# Altium schematic sheet [13] - FPGA BANKS 34 and 35.SchDoc("[13] - FPGA BANKS 34 and 35")
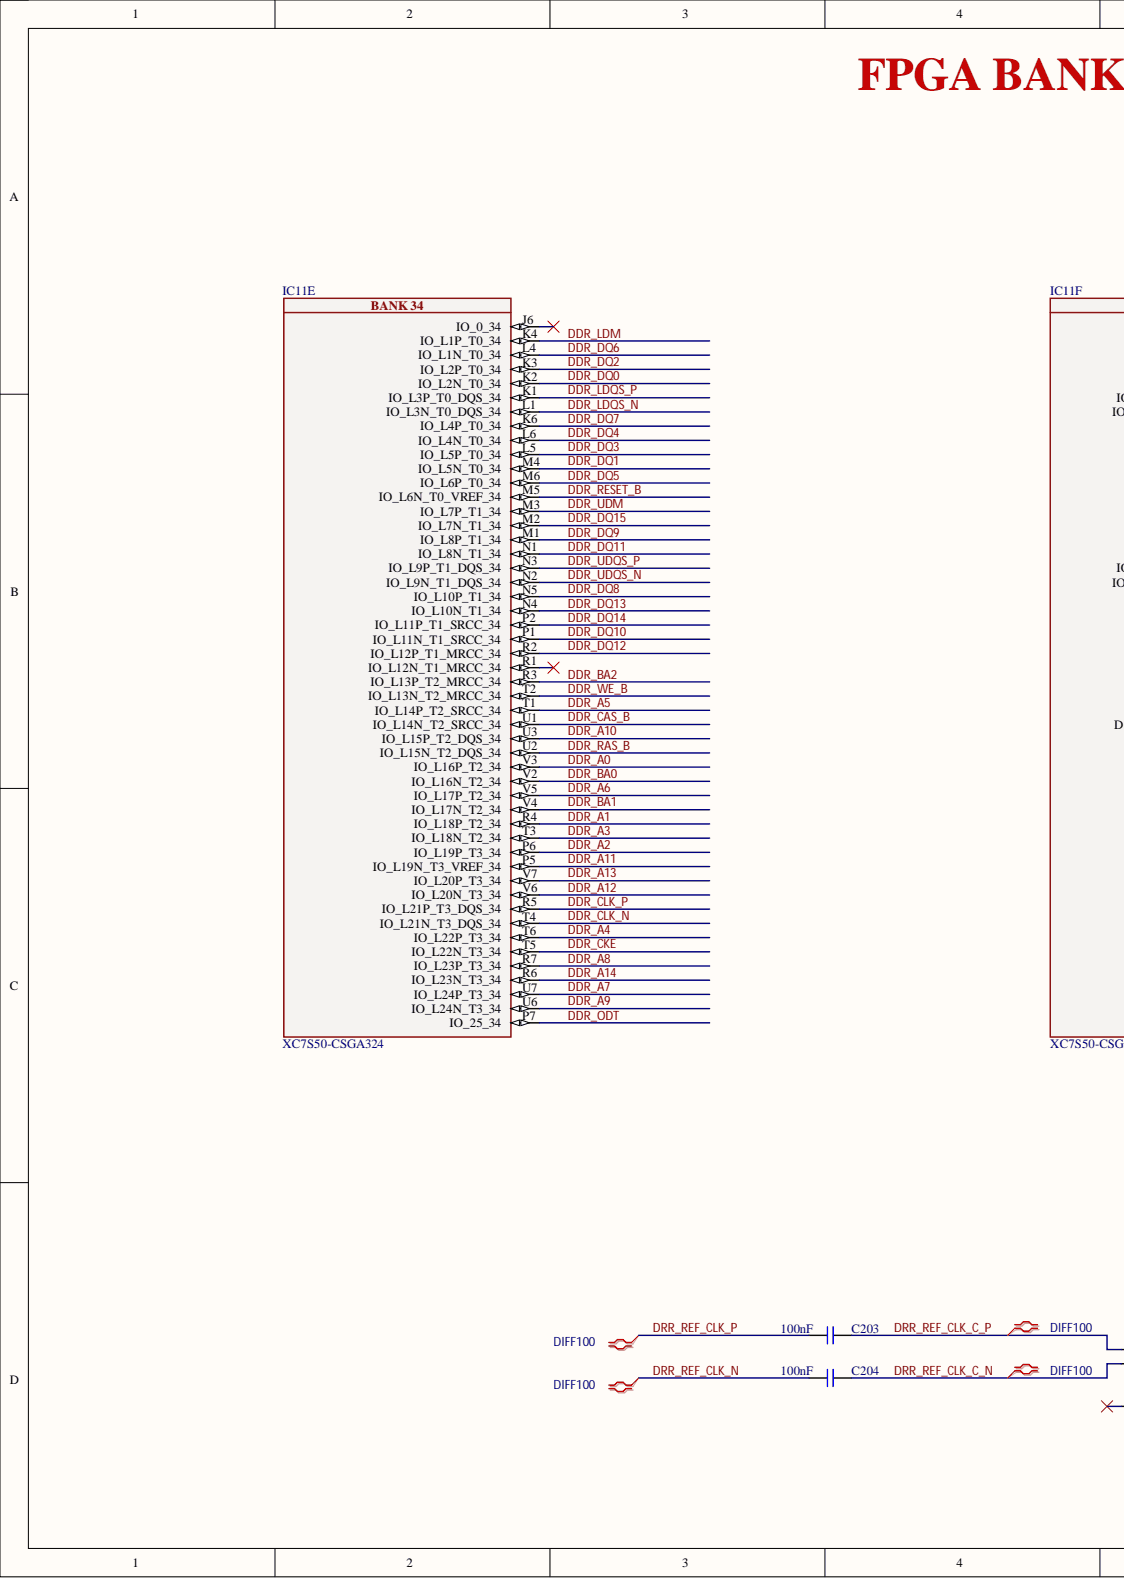
[diagram p0: [13] - FPGA BANKS 34 and 35.SchDoc, left]
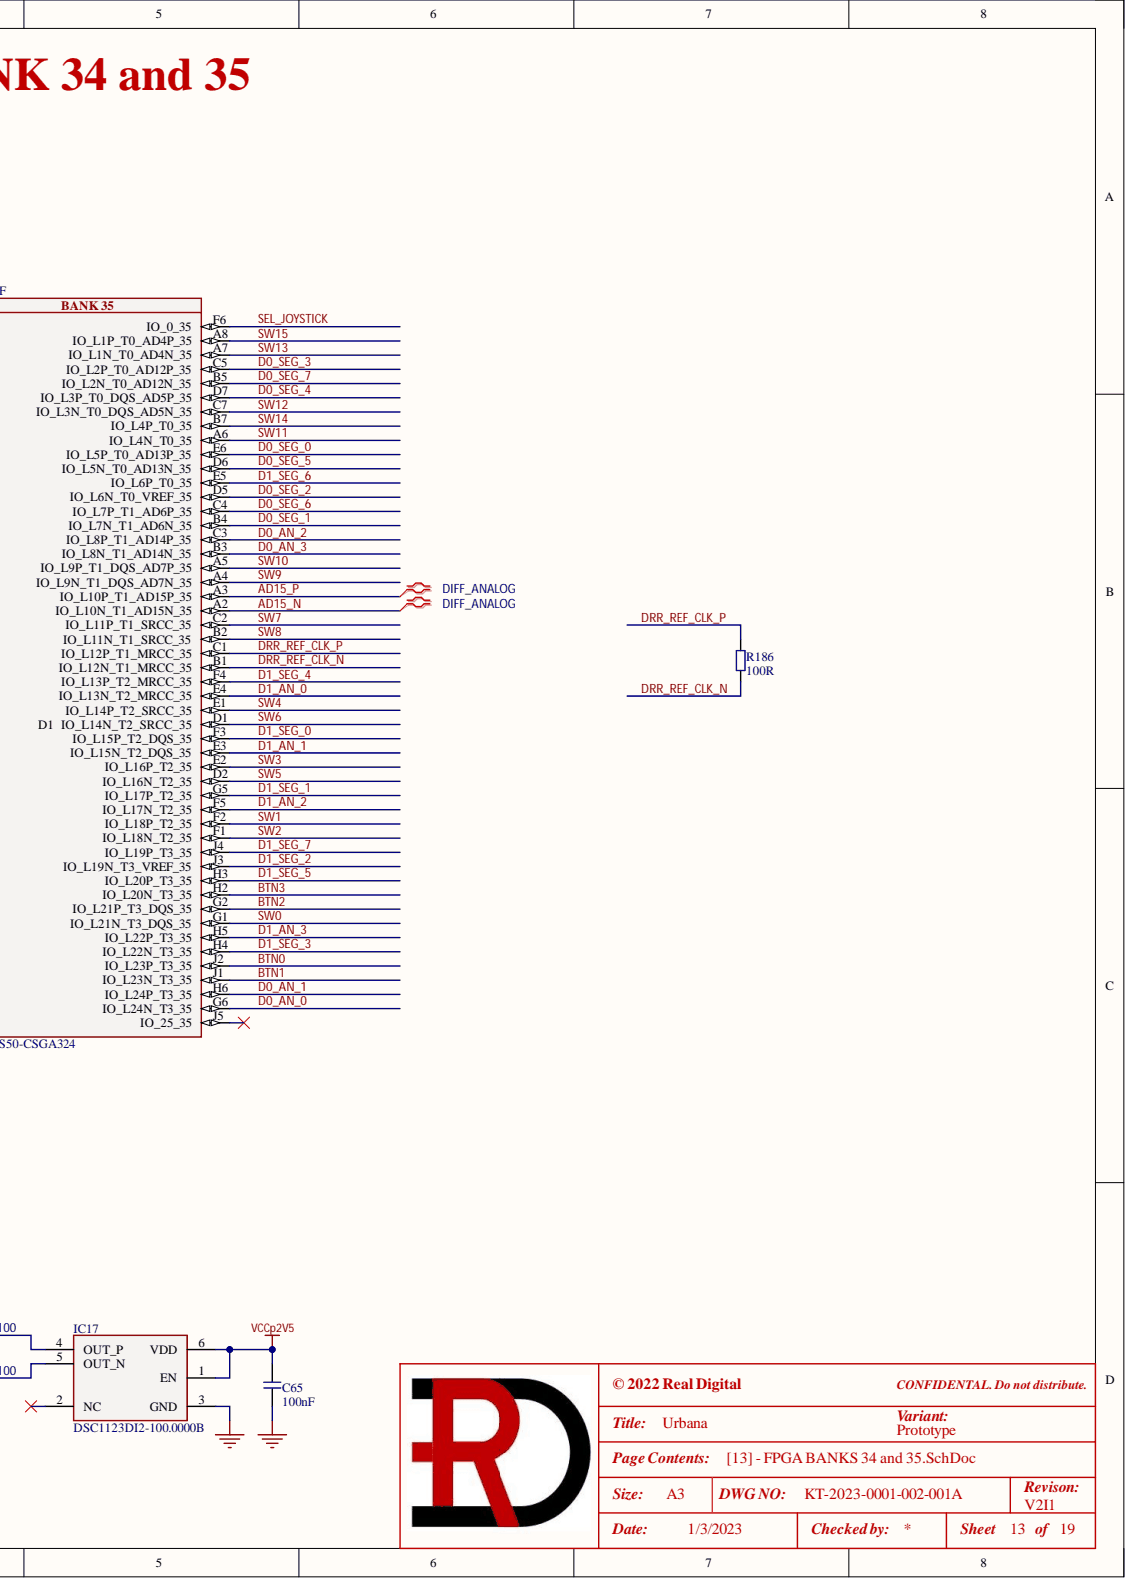
[diagram p1: [13] - FPGA BANKS 34 and 35.SchDoc, right]

## Parts
C65  100nF  [C0402]
C203  100nF  [C0402]
C204  100nF  [C0402]
IC11  XC7S50-CSGA324  [CSG324]  (parts E,F)
IC17  DSC1123DI2-100.0000B  [VDFN6_2_5X2 - MCH]
R186  100R  [R0402]

## Nets
GND: C65.1 IC17.3(GND)
VCCp2V5: C65.2 IC17.1(EN) IC17.6(VDD)
AD15_N: IC11.A2(IO_L10N_T1_AD15N_35)
AD15_P: IC11.A3(IO_L10P_T1_AD15P_35)
BTN0: IC11.J2(IO_L23P_T3_35)
BTN1: IC11.J1(IO_L23N_T3_35)
BTN2: IC11.G2(IO_L21P_T3_DQS_35)
BTN3: IC11.H2(IO_L20N_T3_35)
D0_AN_0: IC11.G6(IO_L24N_T3_35)
D0_AN_1: IC11.H6(IO_L24P_T3_35)
D0_AN_2: IC11.C3(IO_L8P_T1_AD14P_35)
D0_AN_3: IC11.B3(IO_L8N_T1_AD14N_35)
D0_SEG_0: IC11.E6(IO_L5P_T0_AD13P_35)
D0_SEG_1: IC11.B4(IO_L7N_T1_AD6N_35)
D0_SEG_2: IC11.D5(IO_L6N_T0_VREF_35)
D0_SEG_3: IC11.C5(IO_L2P_T0_AD12P_35)
D0_SEG_4: IC11.D7(IO_L3P_T0_DQS_AD5P_35)
D0_SEG_5: IC11.D6(IO_L5N_T0_AD13N_35)
D0_SEG_6: IC11.C4(IO_L7P_T1_AD6P_35)
D0_SEG_7: IC11.B5(IO_L2N_T0_AD12N_35)
D1_AN_0: IC11.E4(IO_L13N_T2_MRCC_35)
D1_AN_1: IC11.E3(IO_L15N_T2_DQS_35)
D1_AN_2: IC11.F5(IO_L17N_T2_35)
D1_AN_3: IC11.H5(IO_L22P_T3_35)
D1_SEG_0: IC11.F3(IO_L15P_T2_DQS_35)
D1_SEG_1: IC11.G5(IO_L17P_T2_35)
D1_SEG_2: IC11.J3(IO_L19N_T3_VREF_35)
D1_SEG_3: IC11.H4(IO_L22N_T3_35)
D1_SEG_4: IC11.F4(IO_L13P_T2_MRCC_35)
D1_SEG_5: IC11.H3(IO_L20P_T3_35)
D1_SEG_6: IC11.E5(IO_L6P_T0_35)
D1_SEG_7: IC11.J4(IO_L19P_T3_35)
DDR_A0: IC11.V3(IO_L16P_T2_34)
DDR_A1: IC11.R4(IO_L18P_T2_34)
DDR_A2: IC11.P6(IO_L19P_T3_34)
DDR_A3: IC11.T3(IO_L18N_T2_34)
DDR_A4: IC11.T6(IO_L22P_T3_34)
DDR_A5: IC11.T1(IO_L14P_T2_SRCC_34)
DDR_A6: IC11.V5(IO_L17P_T2_34)
DDR_A7: IC11.U7(IO_L24P_T3_34)
DDR_A8: IC11.R7(IO_L23P_T3_34)
DDR_A9: IC11.U6(IO_L24N_T3_34)
DDR_A10: IC11.U3(IO_L15P_T2_DQS_34)
DDR_A11: IC11.P5(IO_L19N_T3_VREF_34)
DDR_A12: IC11.V6(IO_L20N_T3_34)
DDR_A13: IC11.V7(IO_L20P_T3_34)
DDR_A14: IC11.R6(IO_L23N_T3_34)
DDR_BA0: IC11.V2(IO_L16N_T2_34)
DDR_BA1: IC11.V4(IO_L17N_T2_34)
DDR_BA2: IC11.R3(IO_L13P_T2_MRCC_34)
DDR_CAS_B: IC11.U1(IO_L14N_T2_SRCC_34)
DDR_CKE: IC11.T5(IO_L22N_T3_34)
DDR_CLK_N: IC11.T4(IO_L21N_T3_DQS_34)
DDR_CLK_P: IC11.R5(IO_L21P_T3_DQS_34)
DDR_DQ0: IC11.K2(IO_L2N_T0_34)
DDR_DQ1: IC11.M4(IO_L5N_T0_34)
DDR_DQ2: IC11.K3(IO_L2P_T0_34)
DDR_DQ3: IC11.L5(IO_L5P_T0_34)
DDR_DQ4: IC11.L6(IO_L4N_T0_34)
DDR_DQ5: IC11.M6(IO_L6P_T0_34)
DDR_DQ6: IC11.L4(IO_L1N_T0_34)
DDR_DQ7: IC11.K6(IO_L4P_T0_34)
DDR_DQ8: IC11.N5(IO_L10P_T1_34)
DDR_DQ9: IC11.M1(IO_L8P_T1_34)
DDR_DQ10: IC11.P1(IO_L11N_T1_SRCC_34)
DDR_DQ11: IC11.N1(IO_L8N_T1_34)
DDR_DQ12: IC11.R2(IO_L12P_T1_MRCC_34)
DDR_DQ13: IC11.N4(IO_L10N_T1_34)
DDR_DQ14: IC11.P2(IO_L11P_T1_SRCC_34)
DDR_DQ15: IC11.M2(IO_L7N_T1_34)
DDR_LDM: IC11.K4(IO_L1P_T0_34)
DDR_LDQS_N: IC11.L1(IO_L3N_T0_DQS_34)
DDR_LDQS_P: IC11.K1(IO_L3P_T0_DQS_34)
DDR_ODT: IC11.P7(IO_25_34)
DDR_RAS_B: IC11.U2(IO_L15N_T2_DQS_34)
DDR_RESET_B: IC11.M5(IO_L6N_T0_VREF_34)
DDR_UDM: IC11.M3(IO_L7P_T1_34)
DDR_UDQS_N: IC11.N2(IO_L9N_T1_DQS_34)
DDR_UDQS_P: IC11.N3(IO_L9P_T1_DQS_34)
DDR_WE_B: IC11.T2(IO_L13N_T2_MRCC_34)
DRR_REF_CLK_C_N: C204.1 IC17.5(OUT_N)
DRR_REF_CLK_C_P: C203.1 IC17.4(OUT_P)
DRR_REF_CLK_N: C204.2 IC11.B1(IO_L12N_T1_MRCC_35) R186.1
DRR_REF_CLK_P: C203.2 IC11.C1(IO_L12P_T1_MRCC_35) R186.2
SEL_JOYSTICK: IC11.F6(IO_0_35)
SW0: IC11.G1(IO_L21N_T3_DQS_35)
SW1: IC11.F2(IO_L18P_T2_35)
SW2: IC11.F1(IO_L18N_T2_35)
SW3: IC11.E2(IO_L16P_T2_35)
SW4: IC11.E1(IO_L14P_T2_SRCC_35)
SW5: IC11.D2(IO_L16N_T2_35)
SW6: IC11.D1(IO_L14N_T2_SRCC_35)
SW7: IC11.C2(IO_L11P_T1_SRCC_35)
SW8: IC11.B2(IO_L11N_T1_SRCC_35)
SW9: IC11.A4(IO_L9N_T1_DQS_AD7N_35)
SW10: IC11.A5(IO_L9P_T1_DQS_AD7P_35)
SW11: IC11.A6(IO_L4N_T0_35)
SW12: IC11.C7(IO_L3N_T0_DQS_AD5N_35)
SW13: IC11.A7(IO_L1N_T0_AD4N_35)
SW14: IC11.B7(IO_L4P_T0_35)
SW15: IC11.A8(IO_L1P_T0_AD4P_35)

Not connected: IC11 J5(IO_25_35) J6(IO_0_34) R1(IO_L12N_T1_MRCC_34); IC17 2(NC)

## Library data of the parts
C65 C203 C204 = GRM155R71E104KE14J: CAP CER 0.1uF 25V X7R 0402; Manufacturer 1: Murata Electronics; .Checked_PCB: AC; .Creator: RV; C P/N: RD-111-0006; LoadStatus: Load; Tolerance: 10%; Category: Capacitors; Component Kind: Standard; Library Name: KT-RealDigital.IntLib; Manufacturer 1 Part Number: GRM155R71E104KE14J; Temperature Coefficient: X7R; Voltage - Rated: 25V; .Checked_SCH: AC
IC11 = XC7S50-CSGA324: FPGA 210 I/O 324CSBGA; Manufacturer 1: Xilinx; .Checked_PCB: RV; C P/N: RD-126-0003-CD; LoadStatus: Load; Category: IC; Component Kind: Standard; Library Name: KT-RealDigital.IntLib; Manufacturer 1 Part Number: XC7S50-CSGA324; .Checked_SCH: RV; .Creator: RV
IC17 = DSC1123DI2-100.0000B: MEMS OSC XO 100.0000MHZ LVDS SMD; Manufacturer 1: Microchip Technology; .Checked_PCB: RV; LoadStatus: Load; Category: IC; Component Kind: Standard; Library Name: KT-RealDigital.IntLib; Manufacturer 1 Part Number: DSC1123DI2-100.0000B; .Checked_SCH: RV; .Creator: RV
R186 = RC0402FR-07100RL: RES SMD 100 OHM 1% 1/16W 0402; Manufacturer 1: Yageo; .Checked_PCB: RV; LoadStatus: Load; Category: Resistors; Component Kind: Standard; Library Name: KT-RealDigital.IntLib; Manufacturer 1 Part Number: RC0402FR-07100RL; Tolerance: 1%; .Checked_SCH: RV; .Creator: RV
